# Altium schematic sheet Sheet1.SchDoc
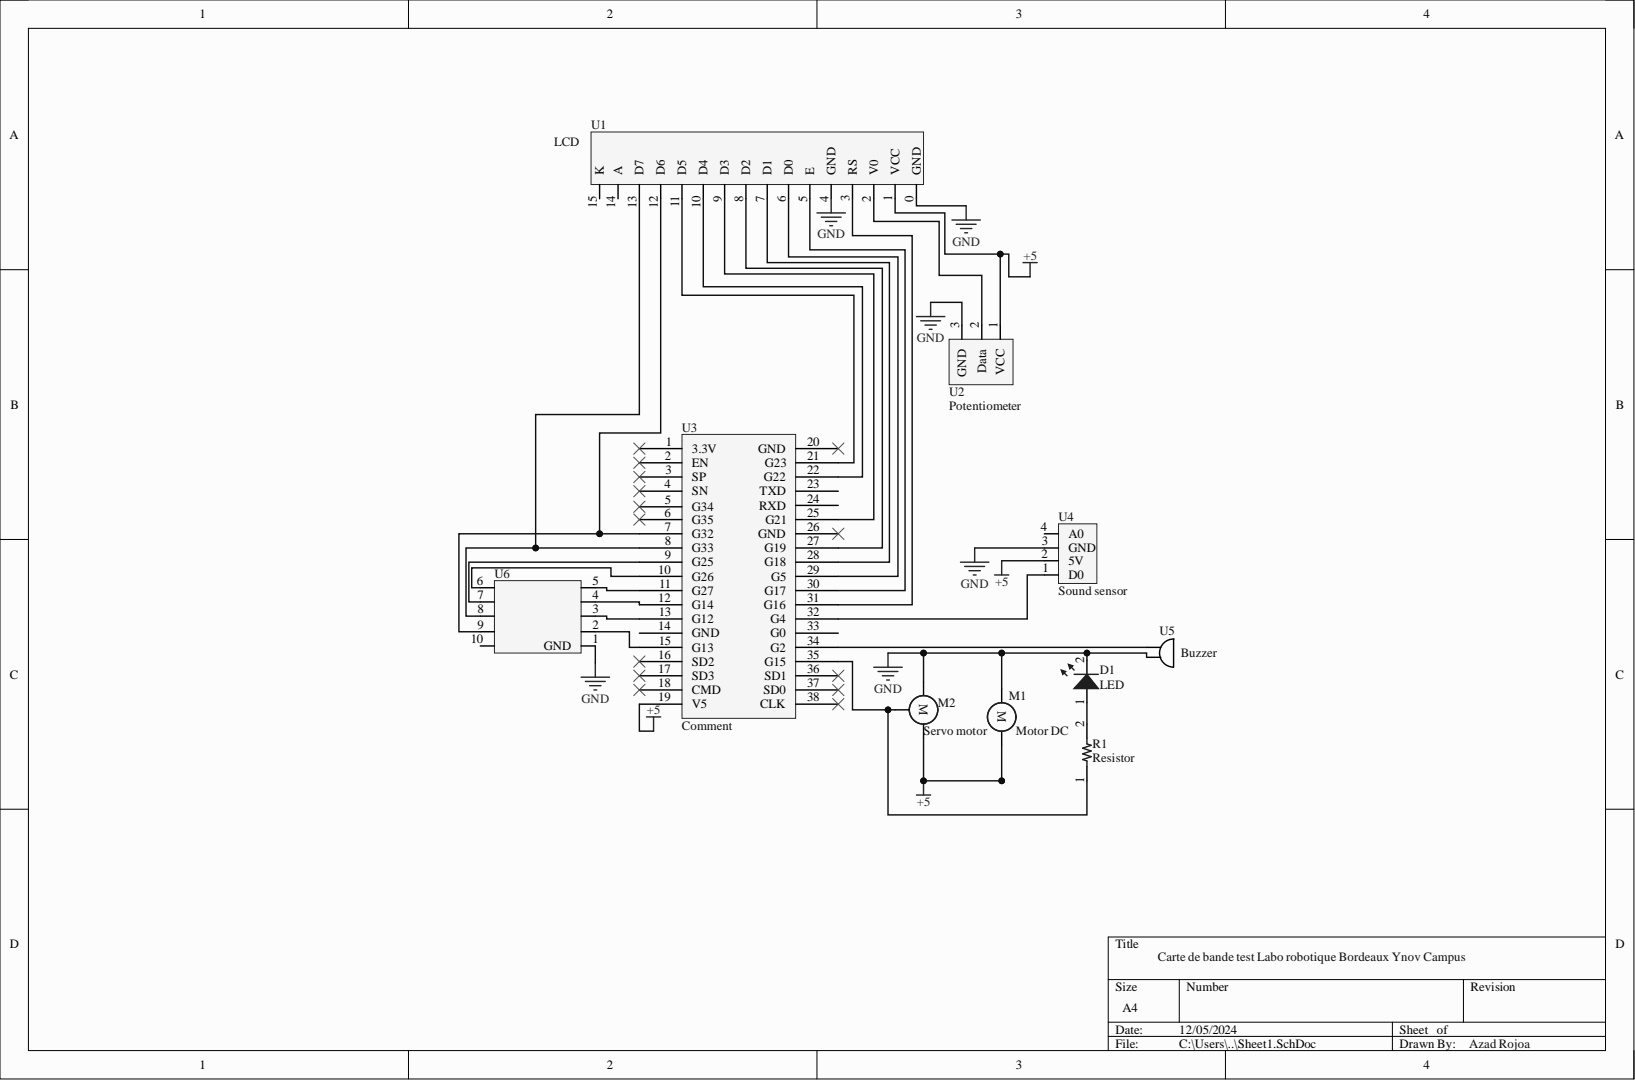
[diagram p0: Sheet1.SchDoc]

## Parts
D1  LED
M1  Motor DC
M2  Servo motor
R1  Resistor
U1  LCD
U2  Potentiometer  [Potentiometer]
U3  Comment
U4  Sound sensor
U5  Buzzer  [Buzzer]
U6  Comment  [7 Segement]  (value not drawn)

## Nets
+5: M1.1 M2.2 U1.1(VCC) U2.1 U3.19(V5) U4.2(5V)
GND: D1.2 M1.0 M2.1 U1.0(GND) U1.4(GND) U2.3 U4.3(GND) U5.2 U6.1(GND)
NetD1_1: D1.1 R1.2
NetM2_3: M2.3 R1.1 U3.35(G15)
NetU1_2: U1.2(V0) U2.2
NetU1_3: U1.3(RS) U3.31(G16)
NetU1_5: U1.5(E) U3.30(G17)
NetU1_6: U1.6(D0) U3.29(G5)
NetU1_7: U1.7(D1) U3.28(G18)
NetU1_8: U1.8(D2) U3.27(G19)
NetU1_9: U1.9(D3) U3.25(G21)
NetU1_10: U1.10(D4) U3.22(G22)
NetU1_11: U1.11(D5) U3.21(G23)
NetU1_12: U1.12(D6) U3.7(G32) U6.9
NetU1_13: U1.13(D7) U3.8(G33) U6.8
NetU3_9: U3.9(G25) U6.7
NetU3_10: U3.10(G26) U6.6
NetU3_11: U3.11(G27) U6.5
NetU3_12: U3.12(G14) U6.4
NetU3_13: U3.13(G12) U6.3
NetU3_15: U3.15(G13) U6.2
NetU3_32: U3.32(G4) U4.1(D0)
NetU3_34: U3.34(G2) U5.1

Not connected: U1 14(A) 15(K); U3 1(3.3V) 2(EN) 3(SP) 4(SN) 5(G34) 6(G35) 14(GND) 16(SD2) 17(SD3) 18(CMD) 20(GND) 23(TXD) 24(RXD) 26(GND) 33(G0) 36(SD1) 37(SD0) 38(CLK); U4 4(A0); U6 10

## Library data of the parts
U3 = ESP32-WROOM-32: Component Kind: Standard; Library Name: Schlib1.SchLib
U6 = 7 Segement: Component Kind: Standard; Library Name: Schliboui.SchLib
D1 = LED: Component Kind: Standard; Library Name: Schliboui.SchLib
M1 = Motor DC: Component Kind: Standard; Library Name: Schlib1.SchLib
M2 = Servo motor: Component Kind: Standard; Library Name: Schliboui.SchLib
R1 = Resistor: Component Kind: Standard; Library Name: Schliboui.SchLib
U1 = LCD: Component Kind: Standard; Library Name: Schliboui.SchLib
U2 = Potentiometer: Component Kind: Standard; Library Name: Schliboui.SchLib
U4 = Sound sensor: Component Kind: Standard; Library Name: Schliboui.SchLib
U5 = Buzzer: Component Kind: Standard; Library Name: Schliboui.SchLib
